# Altium schematic sheet 1. CPU.SchDoc
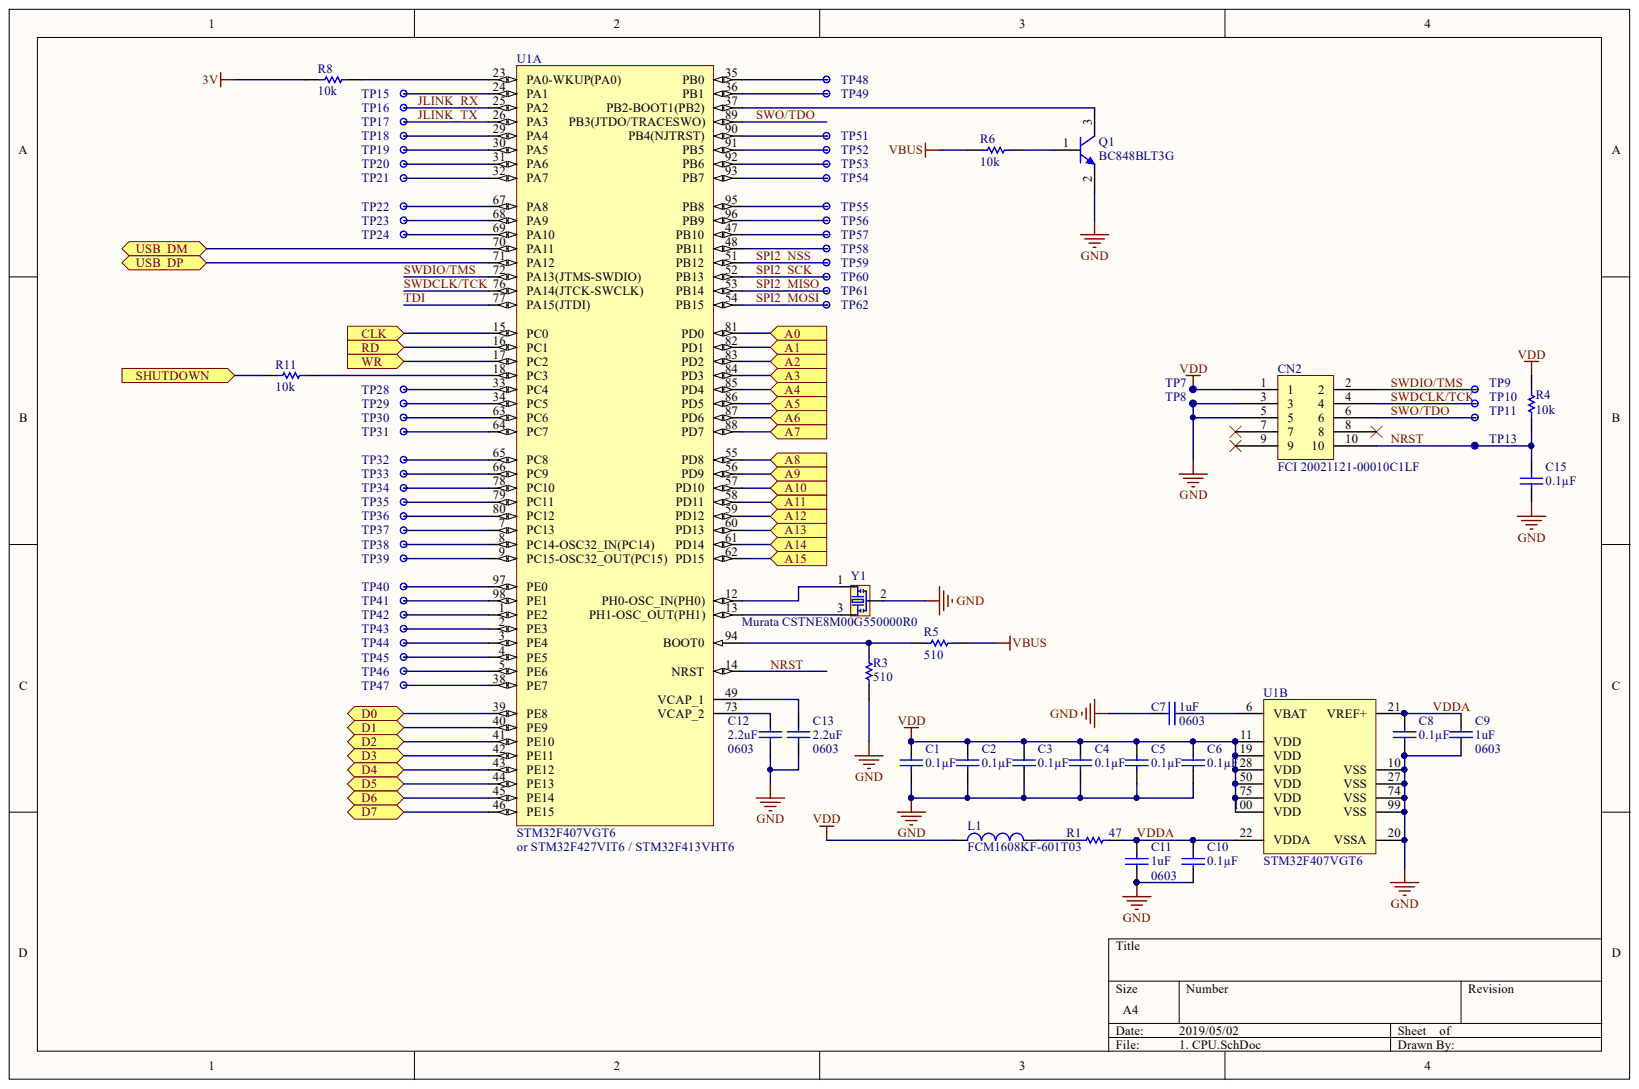
[diagram p0: 1. CPU.SchDoc]

## Parts
C1  Capacitance: 0.1µF  [PCB-mhhqftc5ilnrg4qi8ds6-1]  (hidden comment: Cap)
C2  Capacitance: 0.1µF  [PCB-mhhqftc5ilnrg4qi8ds6-1]  (hidden comment: Cap)
C3  Capacitance: 0.1µF  [PCB-mhhqftc5ilnrg4qi8ds6-1]  (hidden comment: Cap)
C4  Capacitance: 0.1µF  [PCB-mhhqftc5ilnrg4qi8ds6-1]  (hidden comment: Cap)
C5  Capacitance: 0.1µF  [PCB-mhhqftc5ilnrg4qi8ds6-1]  (hidden comment: Cap)
C6  Capacitance: 0.1µF  [PCB-mhhqftc5ilnrg4qi8ds6-1]  (hidden comment: Cap)
C7  Capacitance: 1uF  Case/Package: 0603  [0603C_B]  (hidden comment: Yageo CC0603KRX7R7BB104)
C8  Capacitance: 0.1µF  [PCB-mhhqftc5ilnrg4qi8ds6-1]  (hidden comment: Cap)
C9  Capacitance: 1uF  Case/Package: 0603  [0603C_B]  (hidden comment: Yageo CC0603KRX7R7BB104)
C10  Capacitance: 0.1µF  [PCB-mhhqftc5ilnrg4qi8ds6-1]  (hidden comment: Cap)
C11  Capacitance: 1uF  Case/Package: 0603  [0603C_B]  (hidden comment: Yageo CC0603KRX7R7BB104)
C12  Capacitance: 2.2uF  Case/Package: 0603  [0603C_B]  (hidden comment: Yageo CC0603KRX7R7BB104)
C13  Capacitance: 2.2uF  Case/Package: 0603  [0603C_B]  (hidden comment: Yageo CC0603KRX7R7BB104)
C15  Capacitance: 0.1µF  [PCB-mhhqftc5ilnrg4qi8ds6-1]  (hidden comment: Cap)
CN2  FCI 20021121-00010C1LF  [PCB-ch0b5fvyy24ict2e1x4o-1]
L1  Manufacturer Part Number: FCM1608KF-601T03  [PCB-roep9w497ffvgejiy0y8-1]  (hidden comment: Tai Tech FCM1608KF-601T03)
Q1  BC848BLT3G  [ONSC-SOT-23-3-318-08_V]
R1  YAGEO RC0603JR-0747RL  [R0603_A]  (value not drawn)
R3  YAGEO RC0603JR-07510RL  [R0603_A]  (value not drawn)
R4  Resistance: 10k  [R0603_A]  (hidden comment: YAGEO RC0603JR-0710KL)
R5  YAGEO RC0603JR-07510RL  [R0603_A]  (value not drawn)
R6  Resistance: 10k  [R0603_A]  (hidden comment: YAGEO RC0603JR-0710KL)
R8  Resistance: 10k  [R0603_A]  (hidden comment: YAGEO RC0603JR-0710KL)
R11  Resistance: 10k  [R0603_A]  (hidden comment: YAGEO RC0603JR-0710KL)
TP7  TestPad  [PCB-qqaworq3uifq0h8koz5g-1]  (value not drawn)
TP8  TestPad  [PCB-qqaworq3uifq0h8koz5g-1]  (value not drawn)
TP9  TestPad  [PCB-qqaworq3uifq0h8koz5g-1]  (value not drawn)
TP10  TestPad  [PCB-qqaworq3uifq0h8koz5g-1]  (value not drawn)
TP11  TestPad  [PCB-qqaworq3uifq0h8koz5g-1]  (value not drawn)
TP13  TestPad  [PCB-qqaworq3uifq0h8koz5g-1]  (value not drawn)
TP15  TestPad  [PCB-qqaworq3uifq0h8koz5g-1]  (value not drawn)
TP16  TestPad  [PCB-qqaworq3uifq0h8koz5g-1]  (value not drawn)
TP17  TestPad  [PCB-qqaworq3uifq0h8koz5g-1]  (value not drawn)
TP18  TestPad  [PCB-qqaworq3uifq0h8koz5g-1]  (value not drawn)
TP19  TestPad  [PCB-qqaworq3uifq0h8koz5g-1]  (value not drawn)
TP20  TestPad  [PCB-qqaworq3uifq0h8koz5g-1]  (value not drawn)
TP21  TestPad  [PCB-qqaworq3uifq0h8koz5g-1]  (value not drawn)
TP22  TestPad  [PCB-qqaworq3uifq0h8koz5g-1]  (value not drawn)
TP23  TestPad  [PCB-qqaworq3uifq0h8koz5g-1]  (value not drawn)
TP24  TestPad  [PCB-qqaworq3uifq0h8koz5g-1]  (value not drawn)
TP28  TestPad  [PCB-qqaworq3uifq0h8koz5g-1]  (value not drawn)
TP29  TestPad  [PCB-qqaworq3uifq0h8koz5g-1]  (value not drawn)
TP30  TestPad  [PCB-qqaworq3uifq0h8koz5g-1]  (value not drawn)
TP31  TestPad  [PCB-qqaworq3uifq0h8koz5g-1]  (value not drawn)
TP32  TestPad  [PCB-qqaworq3uifq0h8koz5g-1]  (value not drawn)
TP33  TestPad  [PCB-qqaworq3uifq0h8koz5g-1]  (value not drawn)
TP34  TestPad  [PCB-qqaworq3uifq0h8koz5g-1]  (value not drawn)
TP35  TestPad  [PCB-qqaworq3uifq0h8koz5g-1]  (value not drawn)
TP36  TestPad  [PCB-qqaworq3uifq0h8koz5g-1]  (value not drawn)
TP37  TestPad  [PCB-qqaworq3uifq0h8koz5g-1]  (value not drawn)
TP38  TestPad  [PCB-qqaworq3uifq0h8koz5g-1]  (value not drawn)
TP39  TestPad  [PCB-qqaworq3uifq0h8koz5g-1]  (value not drawn)
TP40  TestPad  [PCB-qqaworq3uifq0h8koz5g-1]  (value not drawn)
TP41  TestPad  [PCB-qqaworq3uifq0h8koz5g-1]  (value not drawn)
TP42  TestPad  [PCB-qqaworq3uifq0h8koz5g-1]  (value not drawn)
TP43  TestPad  [PCB-qqaworq3uifq0h8koz5g-1]  (value not drawn)
TP44  TestPad  [PCB-qqaworq3uifq0h8koz5g-1]  (value not drawn)
TP45  TestPad  [PCB-qqaworq3uifq0h8koz5g-1]  (value not drawn)
TP46  TestPad  [PCB-qqaworq3uifq0h8koz5g-1]  (value not drawn)
TP47  TestPad  [PCB-qqaworq3uifq0h8koz5g-1]  (value not drawn)
TP48  TestPad  [PCB-qqaworq3uifq0h8koz5g-1]  (value not drawn)
TP49  TestPad  [PCB-qqaworq3uifq0h8koz5g-1]  (value not drawn)
TP51  TestPad  [PCB-qqaworq3uifq0h8koz5g-1]  (value not drawn)
TP52  TestPad  [PCB-qqaworq3uifq0h8koz5g-1]  (value not drawn)
TP53  TestPad  [PCB-qqaworq3uifq0h8koz5g-1]  (value not drawn)
TP54  TestPad  [PCB-qqaworq3uifq0h8koz5g-1]  (value not drawn)
TP55  TestPad  [PCB-qqaworq3uifq0h8koz5g-1]  (value not drawn)
TP56  TestPad  [PCB-qqaworq3uifq0h8koz5g-1]  (value not drawn)
TP57  TestPad  [PCB-qqaworq3uifq0h8koz5g-1]  (value not drawn)
TP58  TestPad  [PCB-qqaworq3uifq0h8koz5g-1]  (value not drawn)
TP59  TestPad  [PCB-qqaworq3uifq0h8koz5g-1]  (value not drawn)
TP60  TestPad  [PCB-qqaworq3uifq0h8koz5g-1]  (value not drawn)
TP61  TestPad  [PCB-qqaworq3uifq0h8koz5g-1]  (value not drawn)
TP62  TestPad  [PCB-qqaworq3uifq0h8koz5g-1]  (value not drawn)
U1  STM32F407VGT6  [STM-LQFP100_N]  (parts A,B)
Y1  Murata CSTNE8M00G550000R0  Manufacturer Part Number: CSTNE8M00G550000R0  [CAPMP3213X05M]

## Ports
A0, A1, A2, A3, A4, A5, A6, A7, A8, A9, A10, A11, A12, A13, A14, A15, CLK, D0, D1, D2, D3, D4, D5, D6, D7, RD, SHUTDOWN, USB_DM, USB_DP, WR

## Nets
3V: R8.2
GND: C1.1 C2.1 C3.1 C4.1 C5.1 C6.1 C7.1 C8.1 C9.2 C10.1 C11.2 C12.2 C13.2 C15.1 CN2.3 CN2.5 Q1.2 R3.2 TP8.1 U1.10(VSS) U1.20(VSSA) U1.27(VSS) U1.74(VSS) U1.99(VSS) Y1.2
VBUS: R5.2 R6.2
VDD: C1.2 C2.2 C3.2 C4.2 C5.2 C6.2 CN2.1 L1.1 R4.2 TP7.1 U1.11(VDD) U1.19(VDD) U1.28(VDD) U1.50(VDD) U1.75(VDD) U1.100(VDD)
A0: U1.81(PD0) ; port A0
A1: U1.82(PD1) ; port A1
A2: U1.83(PD2) ; port A2
A3: U1.84(PD3) ; port A3
A4: U1.85(PD4) ; port A4
A5: U1.86(PD5) ; port A5
A6: U1.87(PD6) ; port A6
A7: U1.88(PD7) ; port A7
A8: U1.55(PD8) ; port A8
A9: U1.56(PD9) ; port A9
A10: U1.57(PD10) ; port A10
A11: U1.58(PD11) ; port A11
A12: U1.59(PD12) ; port A12
A13: U1.60(PD13) ; port A13
A14: U1.61(PD14) ; port A14
A15: U1.62(PD15) ; port A15
CLK: U1.15(PC0) ; port CLK
D0: U1.39(PE8) ; port D0
D1: U1.40(PE9) ; port D1
D2: U1.41(PE10) ; port D2
D3: U1.42(PE11) ; port D3
D4: U1.43(PE12) ; port D4
D5: U1.44(PE13) ; port D5
D6: U1.45(PE14) ; port D6
D7: U1.46(PE15) ; port D7
JLINK_RX: TP16.1 U1.25(PA2)
JLINK_TX: TP17.1 U1.26(PA3)
NRST: C15.2 CN2.10 R4.1 TP13.1 U1.14(NRST)
RD: U1.16(PC1) ; port RD
SHUTDOWN: R11.2 ; port SHUTDOWN
SPI2_MISO: TP61.1 U1.53(PB14)
SPI2_MOSI: TP62.1 U1.54(PB15)
SPI2_NSS: TP59.1 U1.51(PB12)
SPI2_SCK: TP60.1 U1.52(PB13)
SWDCLK/TCK: CN2.4 TP10.1 U1.76(PA14(JTCK-SWCLK))
SWDIO/TMS: CN2.2 TP9.1 U1.72(PA13(JTMS-SWDIO))
SWO/TDO: CN2.6 TP11.1 U1.89(PB3(JTDO/TRACESWO))
TDI: U1.77(PA15(JTDI))
USB_DM: U1.70(PA11) ; port USB_DM
USB_DP: U1.71(PA12) ; port USB_DP
VDDA: C8.2 C9.1 C10.2 C11.1 R1.2 U1.21(VREF+) U1.22(VDDA)
WR: U1.17(PC2) ; port WR
NetC7_2: C7.2 U1.6(VBAT)
NetC12_1: C12.1 U1.73(VCAP_2)
NetC13_1: C13.1 U1.49(VCAP_1)
NetL1_2: L1.2 R1.1
NetQ1_1: Q1.1 R6.1
NetQ1_3: Q1.3 U1.37(PB2-BOOT1(PB2))
NetR3_1: R3.1 R5.1 U1.94(BOOT0)
NetR8_1: R8.1 U1.23(PA0-WKUP(PA0))
NetR11_1: R11.1 U1.18(PC3)
NetTP15_1: TP15.1 U1.24(PA1)
NetTP18_1: TP18.1 U1.29(PA4)
NetTP19_1: TP19.1 U1.30(PA5)
NetTP20_1: TP20.1 U1.31(PA6)
NetTP21_1: TP21.1 U1.32(PA7)
NetTP22_1: TP22.1 U1.67(PA8)
NetTP23_1: TP23.1 U1.68(PA9)
NetTP24_1: TP24.1 U1.69(PA10)
NetTP28_1: TP28.1 U1.33(PC4)
NetTP29_1: TP29.1 U1.34(PC5)
NetTP30_1: TP30.1 U1.63(PC6)
NetTP31_1: TP31.1 U1.64(PC7)
NetTP32_1: TP32.1 U1.65(PC8)
NetTP33_1: TP33.1 U1.66(PC9)
NetTP34_1: TP34.1 U1.78(PC10)
NetTP35_1: TP35.1 U1.79(PC11)
NetTP36_1: TP36.1 U1.80(PC12)
NetTP37_1: TP37.1 U1.7(PC13)
NetTP38_1: TP38.1 U1.8(PC14-OSC32_IN(PC14))
NetTP39_1: TP39.1 U1.9(PC15-OSC32_OUT(PC15))
NetTP40_1: TP40.1 U1.97(PE0)
NetTP41_1: TP41.1 U1.98(PE1)
NetTP42_1: TP42.1 U1.1(PE2)
NetTP43_1: TP43.1 U1.2(PE3)
NetTP44_1: TP44.1 U1.3(PE4)
NetTP45_1: TP45.1 U1.4(PE5)
NetTP46_1: TP46.1 U1.5(PE6)
NetTP47_1: TP47.1 U1.38(PE7)
NetTP48_1: TP48.1 U1.35(PB0)
NetTP49_1: TP49.1 U1.36(PB1)
NetTP51_1: TP51.1 U1.90(PB4(NJTRST))
NetTP52_1: TP52.1 U1.91(PB5)
NetTP53_1: TP53.1 U1.92(PB6)
NetTP54_1: TP54.1 U1.93(PB7)
NetTP55_1: TP55.1 U1.95(PB8)
NetTP56_1: TP56.1 U1.96(PB9)
NetTP57_1: TP57.1 U1.47(PB10)
NetTP58_1: TP58.1 U1.48(PB11)
NetU1_12: U1.12(PH0-OSC_IN(PH0)) Y1.1
NetU1_13: U1.13(PH1-OSC_OUT(PH1)) Y1.3

Not connected: CN2 7 8 9

## Library data of the parts
C1-C6 C8 C10 C15 = CMP-1af8d38ac64bc53f-4: CAP CER 0.1UF 50V X7R 0603; Component Kind: Standard; HelpURL: https://media.digikey.com/pdf/Data%20Sheets/Samsung%20PDFs/CL_Series_MLCC_ds.pdf; Library Name: CommunityVault; OctopartID: 1af8d38ac64bc53f; Size / Dimension: 0.063" L x 0.031" W (1.60mm x 0.80mm); Voltage - Rated: 50V
C7 C9 C11-C13 = CMP-123646-11: 0603 0.1 uF 16 V ±10 % Tolerance X7R SMT Multilayer Ceramic Capacitor; Insulation Resistance: 100 GΩ; Lead-Free Status: Lead Free; Voltage Rating (DC): 16.0 V; Component Kind: Standard; Halogen Free Status: Halogen Free; Library Name: CommunityVault; Manufacturer Part Number: CC0603KRX7R7BB104; Mounting Style: Surface Mount; Number of Pins: 2; OctopartID: 6529ac21b1e2baee; Octopart Url: http://octopart.com/cc0603krx7r7bb104-yageo-192941; Packaging: Cut Tape (CT), Tape & Reel (TR); REACH SVHC Compliance: No SVHC; RoHS: Compliant; Seller Landing  Url: https://octopart-clicks.com/click/track?country=US&ak=8157f166&sig=06d218e&sid=1106&ppid=192941&vpid=198824028&ct=offers; Size-Height: 810 µm; Size-Length: 1.60 mm; Size-Width: 810 µm
CN2 = CMP-c6d60ecf2c091911-2: Conn Unshrouded Header HDR 10 POS 1.27mm Solder ST SMD T/R; Component Kind: Standard; Library Name: CommunityVault; OctopartID: c6d60ecf2c091911; Octopart URL: https://octopart.com/20021121-00010c1lf-fci-18075577
L1 = CMP-bcc17660c9806306-2: Magnetic beads, 600R, 350mA, 0603, FCM1608KF-601T03, Tai-tech; DC Resistance: 0.5Ω; Impendance: 600Ω±25%; Test Frequency: 100MHz; Component Kind: Standard; Library Name: CommunityVault; Mounting Style: Surface Mount; OctopartID: bcc17660c9806306; Octopart URL: http://octopart.com/fcm1608kf-601t05-tai+tech-61558823; Rated Current: 350mA; RoHS: Compliant
Q1 = CMP-860696-2: General Purpose Transistor, NPN Silicon, 3-Pin SOT-23, Pb-Free, Tape and Reel; Current Rating: 0.1 A; Lead-Free Status: Lead Free; Voltage Rating (DC): 30.0 V; Case/Package: SOT-23; Component Kind: Standard; Library Name: CommunityVault; Lifecycle Status: Active; Manufacturer Part Number: BC848BLT3G; Mounting Style: Surface Mount; Number of Pins: 3; OctopartID: 31b1f8b7aad2ee76; Octopart Url: https://octopart.com/bc848blt3g-on+semiconductor-835077; Packaging: Tape; Polarity: NPN; RoHS: Compliant
R1 = CMP-c8530329081a1794-1: Res Thick Film 0603 47 Ohm 5% 0.1W(1/10W) ?100ppm/C Molded SMD Paper T/R; Lead-Free Status: Lead Free; Resistance: 47; Voltage Rating (DC): 50.0 V; Case/Package: 0603; Component Kind: Standard; Library Name: CommunityVault; Manufacturer Part Number: RC0603JR-0747RL; Mounting Style: Surface Mount; Number of Pins: 2; OctopartID: c8530329081a1794; Octopart Url: http://octopart.com/rc0603jr-0747rl-yageo-40953135; Power Rating: 0.1 W; REACH SVHC Compliance: No SVHC; Resistance Tolerance: ±5%; RoHS: Compliant; Seller Landing  Url: https://octopart-clicks.com/click/track?country=US&ak=8157f166&sig=0e2277e&sid=5489&ppid=40953135&vpid=190517123&ct=offers; Size-Height: 0.00045 m; Size-Length: 0.0016 m; Size-Width: 0.0008 m
R3 R5 = CMP-c8530329081a1794-1: Res Thick Film 0603 47 Ohm 5% 0.1W(1/10W) ?100ppm/C Molded SMD Paper T/R; Lead-Free Status: Lead Free; Resistance: 510; Voltage Rating (DC): 50.0 V; Case/Package: 0603; Component Kind: Standard; Library Name: CommunityVault; Manufacturer Part Number: RC0603JR-07510RL; Mounting Style: Surface Mount; Number of Pins: 2; OctopartID: c8530329081a1794; Power Rating: 0.1 W; REACH SVHC Compliance: No SVHC; Resistance Tolerance: ±5%; RoHS: Compliant; Size-Height: 0.00045 m; Size-Length: 0.0016 m; Size-Width: 0.0008 m
R4 R6 R8 R11 = CMP-c8530329081a1794-1: Res Thick Film 0603 47 Ohm 5% 0.1W(1/10W) ?100ppm/C Molded SMD Paper T/R; Lead-Free Status: Lead Free; Voltage Rating (DC): 50.0 V; Case/Package: 0603; Component Kind: Standard; Library Name: CommunityVault; Manufacturer Part Number: RC0603JR-0710KL; Mounting Style: Surface Mount; Number of Pins: 2; OctopartID: c8530329081a1794; Power Rating: 0.1 W; REACH SVHC Compliance: No SVHC; Resistance Tolerance: ±5%; RoHS: Compliant; Size-Height: 0.00045 m; Size-Length: 0.0016 m; Size-Width: 0.0008 m
TP7-TP11 TP13 TP15-TP24 TP28-TP49 TP51-TP62 = CMP-822f97gjbv438nmxigx5-1: Component Kind: Standard; CustomComponentID: 822f97gjbv438nmxigx5; Description: TestPad; Library Name: CommunityVault
U1 = STM32F407VGT6-4: ARM Cortex-M4 32-bit MCU+FPU, 210 DMIPS, 1024 kB Flash, 192 kB Internal RAM, 82 I/Os, 100-pin LQFP, -40 to 85 degC, Tray; Clock Speed: 168000000.0 Hz; Lead-Free Status: Lead Free; RAM Memory Size: 200704 B; Component Kind: Standard; Library Name: FavoritesLibrary; Lifecycle Status: Active; Mounting Style: Surface Mount; Number of Pins: 100; OctopartID: 15bbdd88ef23ca7c; Octopart Url: https://octopart.com/stm32f407vgt6-stmicroelectronics-20570110; REACH SVHC Compliance: No SVHC; RoHS: Compliant
Y1 = CMP-9b4a57327c60448d-4: Ceramic Resonator 8MHz ±0.5% (Tol) ±0.3% (Stability) 15pF 40Ohm 3-Pin CSMD T/R; Component Kind: Standard; Library Name: CommunityVault; OctopartID: 9b4a57327c60448d; Octopart URL: https://octopart.com/cstne16m0v530000r0-murata-91406924
